# Altium schematic sheet Driver.SchDoc
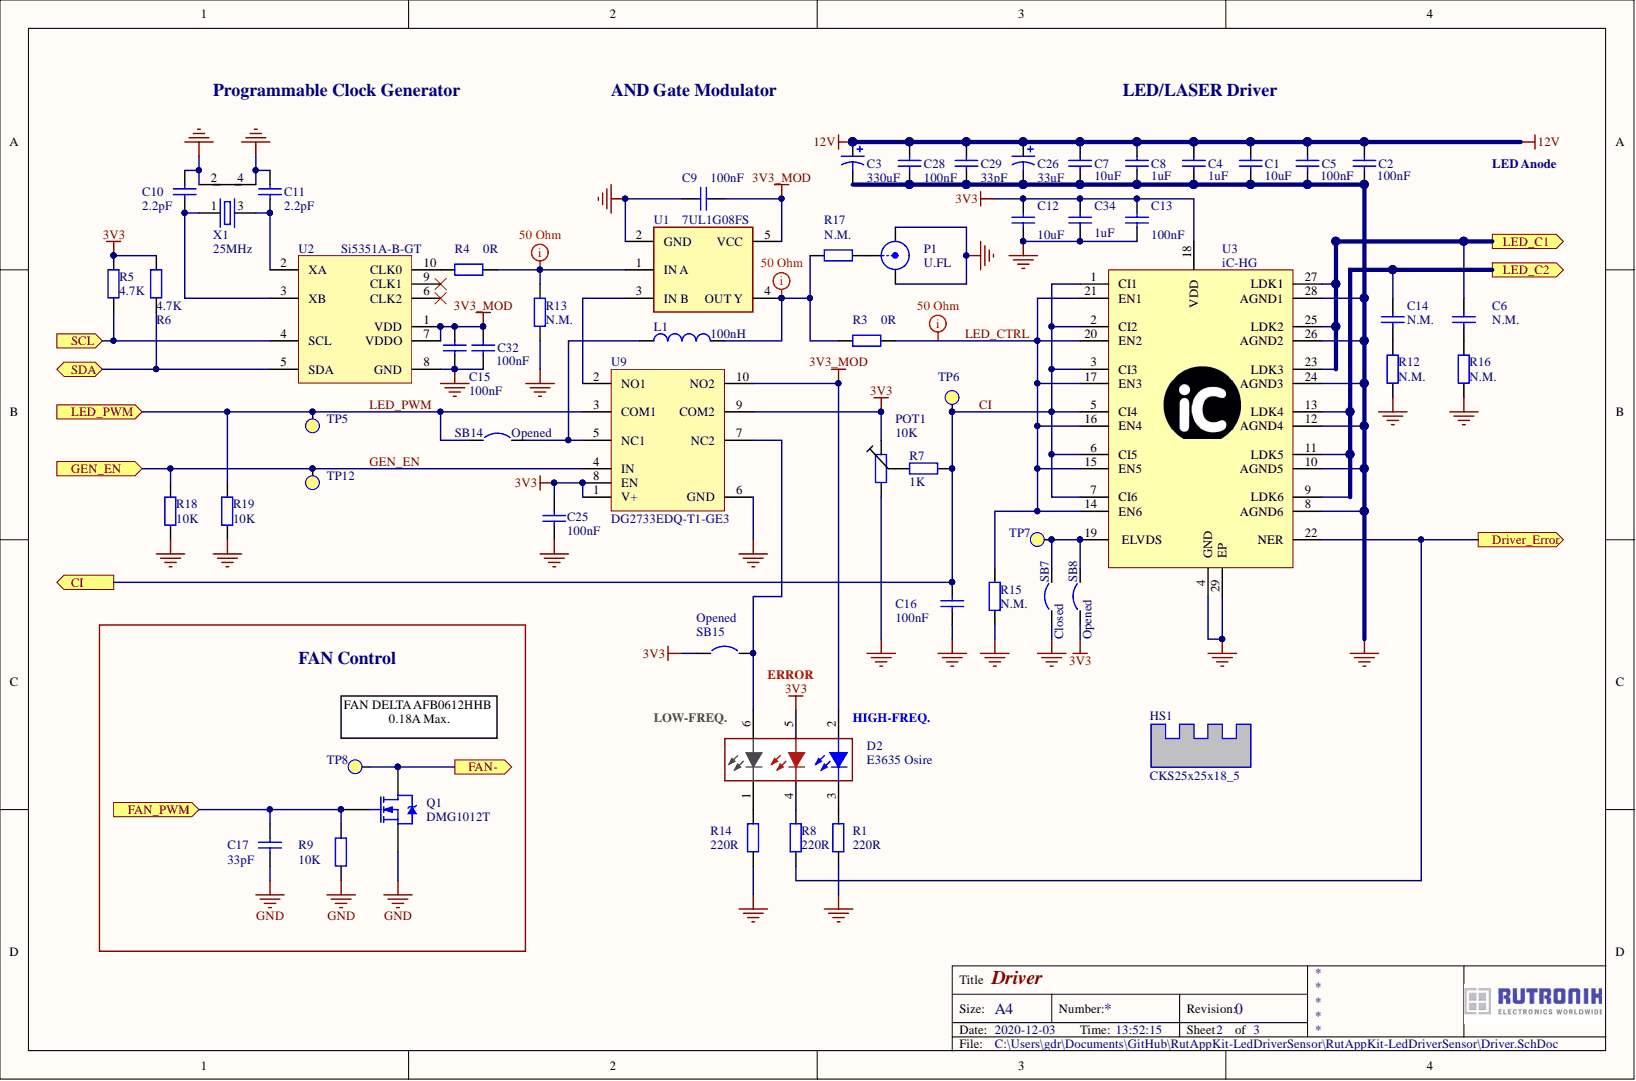
[diagram p0: Driver.SchDoc]

## Parts
C1  value 10uF  [C0805]  (hidden comment: Cap Semi)
C2  value 100nF  [C0402]  (hidden comment: Cap Semi)
C3  value 330uF  [Cap_6.3_7.7_SMD]  (hidden comment: electrolytic capacitor)
C4  value 1uF  [C0805]  (hidden comment: Cap Semi)
C5  value 100nF  [C0402]  (hidden comment: Cap Semi)
C6  value N.M.  [C0603]  (hidden comment: Cap Semi)  (no BOM)
C7  value 10uF  [C0805]  (hidden comment: Cap Semi)
C8  value 1uF  [C0805]  (hidden comment: Cap Semi)
C9  value 100nF  [C0402]  (hidden comment: Cap Semi)
C10  value 2.2pF  [C0402]  (hidden comment: Cap Semi)
C11  value 2.2pF  [C0402]  (hidden comment: Cap Semi)
C12  value 10uF  [C0805]  (hidden comment: Cap Semi)
C13  value 100nF  [C0402]  (hidden comment: Cap Semi)
C14  value N.M.  [C0603]  (hidden comment: Cap Semi)  (no BOM)
C15  value 100nF  [C0402]  (hidden comment: Cap Semi)
C16  value 100nF  [C0402]  (hidden comment: Cap Semi)
C17  value 33pF  [C0402]  (hidden comment: Cap Semi)
C25  value 100nF  [C0402]  (hidden comment: Cap Semi)
C26  value 33uF  [Case-B]  (hidden comment: electrolytic capacitor)
C28  value 100nF  [C0402]  (hidden comment: Cap Semi)
C29  value 33pF  [C0402]  (hidden comment: Cap Semi)
C32  value 100nF  [C0402]  (hidden comment: Cap Semi)
C34  value 1uF  [C0805]  (hidden comment: Cap Semi)
D2  E3635 Osire  [PlCC6_led avago]
HS1  CKS25x25x18_5  [ICKS25x25x18_5]
L1  value 100nH  [L0402]  (hidden comment: Inductor)
P1  U.FL  [U.FL_Connector_Male]
POT1  value 10K  [T93XA]  (hidden comment: Trimmer)
Q1  DMG1012T  [SOT-523_custom]
R1  value 220R  [R0402]  (hidden comment: Res2)
R3  value 0R  [R0402]  (hidden comment: Res2)
R4  value 0R  [R0402]  (hidden comment: Res2)
R5  value 4.7K  [R0402]  (hidden comment: Res2)
R6  value 4.7K  [R0402]  (hidden comment: Res2)
R7  value 1K  [R0402]  (hidden comment: Res2)
R8  value 220R  [R0402]  (hidden comment: Res2)
R9  value 10K  [R0402]  (hidden comment: Res2)
R12  value N.M.  [R0603]  (hidden comment: Res2)  (no BOM)
R13  value N.M.  [R0402]  (hidden comment: Res2)  (no BOM)
R14  value 220R  [R0402]  (hidden comment: Res2)
R15  value N.M.  [R0402]  (hidden comment: Res2)  (no BOM)
R16  value N.M.  [R0603]  (hidden comment: Res2)  (no BOM)
R17  value N.M.  [R0402]  (hidden comment: Res2)  (no BOM)
R18  value 10K  [R0402]  (hidden comment: Res2)
R19  value 10K  [R0402]  (hidden comment: Res2)
SB7  Closed  [SB_0402]
SB8  Opened  [SB_0402 - open]  (no BOM)
SB14  Opened  [SB_0402 - open]  (no BOM)
SB15  Opened  [SB_0402 - open]  (no BOM)
TP5  Test_Point  [TEST_POINT]  (value not drawn)  (no BOM)
TP6  Test_Point  [TEST_POINT]  (value not drawn)  (no BOM)
TP7  Test_Point  [TEST_POINT]  (value not drawn)  (no BOM)
TP8  Test_Point  [TEST_POINT]  (value not drawn)  (no BOM)
TP12  Test_Point  [TEST_POINT]  (value not drawn)  (no BOM)
U1  7UL1G08FS  [SOT-953]
U2  Si5351A-B-GT  [MSOP10]
U3  iC-HG  [QFN28-5x5]
U9  DG2733EDQ-T1-GE3  [MSOP10]
X1  25MHz  [XTAL_3.5x2.5]

## Ports
CI, Driver_Error, FAN-, FAN_PWM, GEN_EN, LED_C1, LED_C2, LED_PWM, SCL, SDA

## Nets
3V3: C12.2 C13.2 C25.1 C34.2 D2.5 POT1.3 R5.1 R6.1 SB8.1 SB15.1 U3.18(VDD) U9.1(V+) U9.8(EN) U9.9(COM2)
3V3_MOD: C9.1 C15.1 C32.1 D2.2 U1.5(VCC) U2.1(VDD) U2.7(VDDO) U9.10(NO2)
12V: C1.2 C2.2 C3.1 C4.2 C5.2 C7.2 C8.2 C26.1 C28.2 C29.1
GND: C1.1 C2.1 C3.2 C4.1 C5.1 C7.1 C8.1 C9.2 C10.1 C11.1 C12.1 C13.1 C15.2 C16.1 C17.2 C25.2 C26.2 C28.1 C29.2 C32.2 C34.1 P1.2 P1.3 POT1.1 Q1.3 R1.2 R9.1 R12.1 R13.2 R14.2 R15.1 R16.1 R18.2 R19.2 SB7.1 U1.2(GND) U2.8(GND) U3.4(GND) U3.8(AGND6) U3.10(AGND5) U3.12(AGND4) U3.24(AGND3) U3.26(AGND2) U3.28(AGND1) U3.29(EP) U9.6(GND) X1.2 X1.4
CI: C16.2 R7.2 TP6.0 U3.1(CI1) U3.2(CI2) U3.3(CI3) U3.5(CI4) U3.6(CI5) U3.7(CI6) ; port CI
Driver_Error: R8.1 U3.22(NER) ; port Driver_Error
FAN-: Q1.2 TP8.0 ; port FAN-
FAN_PWM: C17.1 Q1.1 R9.2 ; port FAN_PWM
GEN_EN: R18.1 TP12.0 U9.4(IN) ; port GEN_EN
LED_C1: C6.2 U3.23(LDK3) U3.25(LDK2) U3.27(LDK1) ; port LED_C1
LED_C2: C14.2 U3.9(LDK6) U3.11(LDK5) U3.13(LDK4) ; port LED_C2
LED_CTRL: R3.2 R15.2 U3.14(EN6) U3.15(EN5) U3.16(EN4) U3.17(EN3) U3.20(EN2) U3.21(EN1)
LED_PWM: R19.1 SB14.1 TP5.0 U9.3(COM1) ; port LED_PWM
SCL: R5.2 U2.4(SCL) ; port SCL
SDA: R6.2 U2.5(SDA) ; port SDA
NetC6_1: C6.1 R16.2
NetC10_2: C10.2 U2.3(XB) X1.1
NetC11_2: C11.2 U2.2(XA) X1.3
NetC14_1: C14.1 R12.2
NetD2_1: D2.1 R14.1
NetD2_3: D2.3 R1.1
NetD2_4: D2.4 R8.2
NetD2_6: D2.6 SB15.2 U9.7(NC2)
NetL1_1: L1.1 SB14.2 U9.5(NC1)
NetL1_2: L1.2 R3.1 R17.1 U1.4(OUT_Y)
NetP1_1: P1.1 R17.2
NetPOT1_2: POT1.2 R7.1
NetR4_1: R4.1 U2.10(CLK0)
NetR4_2: R4.2 R13.1 U1.1(IN_A)
NetSB7_2: SB7.2 SB8.2 TP7.0 U3.19(ELVDS)
NetU1_3: U1.3(IN_B) U9.2(NO1)

Not connected: U2 6(CLK2) 9(CLK1)

## Library data of the parts
C1 C7 C12 = Cap Semi: Capacitor (Semiconductor SIM Model); Manufacturer: Samsung EM; Manufacturer Part No.: CL21B106KOQNNNG; Part Description: Ceramic Capacitor; Specification: X7R, 16V, 10%; Component Kind: Standard; Library Name: Miscellaneous Devices.IntLib; Link: https://www.rutronik24.com/product/samsung+em/cl21b106koqnnng/32265.html; Signal Integrity: Cap Semi; Simulation: CAP; Supplier: Rutronik; Supplier part number: KKS2640
C2 C5 C9 C13 C15 C16 C25 C28 C32 = Cap Semi: Capacitor (Semiconductor SIM Model); Manufacturer: Samsung EM; Manufacturer Part No.: CL05B104KO5NNNC; Part Description: Ceramic Capacitor; Specification: X7R, 16V, 10%; Component Kind: Standard; Library Name: Miscellaneous Devices.IntLib; Link: https://www.rutronik24.com/product/samsung+em/cl05b104ko5nnnc/4748662.html; Signal Integrity: Cap Semi; Simulation: CAP; Supplier: Rutronik; Supplier part number: KKK21419
C3 = Cap Pol1: Manufacturer: Panasonic; Manufacturer Part No.: EEE-FTC331XAP; Specification: 16V, 20%; Component Kind: Standard; Library Name: Miscellaneous Devices.IntLib; Link: https://www.rutronik24.com/search-result/qs:EEE-FTC331XAP/reset:0; Part Description: Electrolytic Capacitor; Signal Integrity: Cap Pol; Simulation: CAP; Supplier: Rutronik; Supplier part number: KEL20909
C4 C8 C34 = Cap Semi: Capacitor (Semiconductor SIM Model); Manufacturer: Samsung EM; Manufacturer Part No.: CL21B105KOFNNNF; Part Description: Ceramic Capacitor; Specification: X7R, 16V, 10%; Component Kind: Standard; Library Name: Miscellaneous Devices.IntLib; Link: https://www.rutronik24.com/product/samsung+em/cl21b105kofnnnf/30238.html; Signal Integrity: Cap Semi; Simulation: CAP; Supplier: Rutronik; Supplier part number: KKK16216
C6 C14 = Cap Semi: Capacitor (Semiconductor SIM Model); Part Description: Ceramic Capacitor; Specification: X7R, 50V, 10%; Library Name: Miscellaneous Devices.IntLib; Signal Integrity: Cap Semi; Simulation: CAP; Supplier: Rutronik
C10 C11 = Cap Semi: Capacitor (Semiconductor SIM Model); Manufacturer: Yageo; Manufacturer Part No.: CC0402CRNPO9BN2R2; Part Description: Ceramic Capacitor; Specification: NP0, 50V, 0.25pf; Component Kind: Standard; Library Name: Miscellaneous Devices.IntLib; Link: https://www.rutronik24.com/product/yageo/cc0402crnpo9bn2r2/30956.html; Signal Integrity: Cap Semi; Simulation: CAP; Supplier: Rutronik; Supplier part number: KKK19155
C17 C29 = Cap Semi: Capacitor (Semiconductor SIM Model); Manufacturer: Samsung EM; Manufacturer Part No.: CL05C330JB5NNNC; Part Description: Ceramic Capacitor; Specification: NP0, 50V, 5%; Component Kind: Standard; Library Name: Miscellaneous Devices.IntLib; Link: https://www.rutronik24.com/product/samsung+em/cl05c330jb5nnnc/29970.html; Signal Integrity: Cap Semi; Simulation: CAP; Supplier: Rutronik; Supplier part number: KKK15073
C26 = Cap Pol1: Manufacturer: AVX; Manufacturer Part No.: TCNL336M016R0200E; Part Description: Tantalum Polymer Capacitor; Specification: 16V, 20%; Component Kind: Standard; Library Name: Miscellaneous Devices.IntLib; Link: https://www.rutronik24.com/search-result/qs:TCNL336M016R0200E/reset:0; Signal Integrity: Cap Pol; Simulation: CAP; Supplier: Rutronik; Supplier part number: TCNL336M016R0200E
D2 = E3635: RGB LED; Manufacturer: OSRAM; Manufacturer Part No.: LRTBGVSR-U4V2-JW+A6BB-D8+S2U2-7Z-20-S; Rule: Clearance Constraint [Clearance = 0.2mm]; Specification: 626 nm, 528 nm, 465 nm; Value: LRTBGVSR-U4V2-JW+A6BB-D8+S2U2-7Z-20-S; Component Kind: Standard; Link: https://www.osram.com/ecat/OSIRE%C2%AE%20E3635%20LRTBGVSR/com/en/class_pim_web_catalog_103489/global/prd_pim_device_5154412/; Part Description: RGB LED; Supplier: Rutronik; Supplier part number: LRTBGVSR-U4V2-JW+A6BB-D8+S2U2-7Z-20-S; Library Name: RutAppKit-LedDriverSensor.SCHLIB
HS1 = CKS25x25x18_5: 5 x 25 x 18,5 mm, pin heatsink quadratic; Manufacturer: Fischer Elektronik; Manufacturer Part No.: ICK S 25x25x18,5; Specification: 25 x 25 x 18,5 mm; Value: ICK S 25x25x18,5; Component Kind: Standard; Library Name: CKS25x25x18_5.SchLib; Link: https://www.rutronik24.com/product/fischer/ick+s+25+x+25+x+18%2C5/12693988.html; Part Description: Pin heatsink quadratic; Supplier: Rutronik; Supplier part number: HSINK2003
L1 = Inductor: Manufacturer: muRata; Manufacturer Part No.: LQG15WHR10J02D; Specification: 150mA 5%; Component Kind: Standard; Description: Inductor; Library Name: Miscellaneous Devices.IntLib; Link: https://www.rutronik24.com/search-result/qs:LQG15WHR10J02D/reset:0; Part Description: Inductor; Signal Integrity: Inductor; Simulation: INDUCTOR; Supplier: Rutronik; Supplier part number: LQG15WHR10J02D
P1 = SMB: Manufacturer: HIROSE; Manufacturer Part No.: U.FL-R-SMT-1(10); Part Description: U.FL Connector; Specification: Male; Value: U.FL-R-SMT-1(10); Component Kind: Standard; Link: https://www.hirose.com/product/en/products/U.FL/U.FL-R-SMT-1%2810%29/; Signal Integrity: Connector; Supplier: Rutronik; Supplier part number: COREC23028; Library Name: RUT_L865_Project_1.SCHLIB
POT1 = Trimmer: Manufacturer: Vishay; Manufacturer Part No.: T93XA103KT20; Specification: 10% 0,5W; Component Kind: Standard; Link: https://www.rutronik24.com/search-result/qs:T93XA103KT20/reset:0; Part Description: Trimmer; Signal Integrity: Res; Simulation: RESISTOR; Supplier: Rutronik; Supplier part number: T93XA103KT20; Library Name: RutAppKit-LedDriverSensor.SCHLIB
Q1 = NMOS-2: N-Channel Power MOSFET; Manufacturer: Diodes Inc.; Manufacturer Part No.: DMG1012T-7; Part Description: N-Channel MOSFET; Specification: 20V, 0.63A; Value: DMG1012T-7; Component Kind: Standard; Library Name: Miscellaneous Devices.IntLib; Link: https://www.rutronik24.com/product/diodes+inc/dmg1012t-7/5168665.html; Simulation: IRF1010; Supplier: Rutronik; Supplier part number: TMOSS6623
R1 R8 R14 = Res2: Manufacturer: ASJ; Manufacturer Part No.: RC0402JR-0710KL; Part Description: Thick Film Resistor; Specification: 5% 0,063W; Component Kind: Standard; Library Name: Miscellaneous Devices.IntLib; Link: https://www.rutronik24.com/product/asj/cr10-221-jk/51883.html; Signal Integrity: Res; Simulation: RESISTOR; Supplier: Rutronik; Supplier part number: WRC35108
R3 R4 = Res2: Manufacturer: Yageo; Manufacturer Part No.: RC04020R; Part Description: Thick Film Resistor; Specification: 5% 0,063W; Component Kind: Standard; Library Name: Miscellaneous Devices.IntLib; Link: https://www.rutronik24.com/product/yageo/ac0402fr-070rl/1082692.html; Signal Integrity: Res; Simulation: RESISTOR; Supplier: Rutronik; Supplier part number: WRC52534
R5 R6 = Res2: Manufacturer: Yageo; Manufacturer Part No.: RC0402JR-134K7L; Part Description: Thick Film Resistor; Specification: 5% 0,063W; Component Kind: Standard; Library Name: Miscellaneous Devices.IntLib; Link: https://www.rutronik24.com/product/yageo/rc0402jr-134k7l/56100.html; Signal Integrity: Res; Simulation: RESISTOR; Supplier: Rutronik; Supplier part number: WRC46913
R7 = Res2: Manufacturer: Yageo; Manufacturer Part No.: RC0402JR-071KL; Part Description: Thick Film Resistor; Specification: 5% 0,063W; Component Kind: Standard; Library Name: Miscellaneous Devices.IntLib; Link: https://www.rutronik24.com/search-result/nojs:1337/qs:RC0402JR-071KL/reset:0; Signal Integrity: Res; Simulation: RESISTOR; Supplier: Rutronik; Supplier part number: WRC33833
R9 R18 R19 = Res2: Manufacturer: ASJ; Manufacturer Part No.: CR10-103-JK; Part Description: Thick Film Resistor; Specification: 5% 0,063W; Component Kind: Standard; Library Name: Miscellaneous Devices.IntLib; Link: https://www.rutronik24.com/product/asj/cr10-103-jk/54295.html; Signal Integrity: Res; Simulation: RESISTOR; Supplier: Rutronik; Supplier part number: WRC40976
R12 R13 R15-R17 = Res2: Part Description: Thick Film Resistor; Specification: 5% 0,063W; Library Name: Miscellaneous Devices.IntLib; Signal Integrity: Res; Simulation: RESISTOR; Supplier: Rutronik
SB7 SB8 SB14 SB15 = Circuit Breaker_close: Circuit Breaker; Manufacturer: Yageo; Manufacturer Part No.: RC04020R; Part Description: Thick Film Resistor; Specification: 5% 0,063W; Value: 0R; Link: https://www.rutronik24.com/product/yageo/ac0402fr-070rl/1082692.html; Revision: July-2002: Re-released for DXP Platform.; Supplier: Rutronik; Supplier part number: WRC52534; Library Name: RutAppKit-LedDriverSensor.SCHLIB
  SB7: Component Kind: Standard
TP5-TP8 TP12 = Test_Point: Test Point; Library Name: Test Point.SchLib
U1 = 7UL1G08FS: 2-Input AND Gate; Manufacturer: TOSHIBA; Manufacturer Part No.: 7UL1G08FS,LF; Rule: Clearance Constraint [Clearance = 0.15mm]; Specification: 3.3V, 2.5ns; Value: 7UL1G08FS,LF; Component Kind: Standard; Library Name: 7UL1G08FS.SchLib; Link: https://toshiba.semicon-storage.com/ap-en/semiconductor/product/general-purpose-logic-ics/detail.7UL1G08FS.html; Part Description: 2-Input AND Gate; Supplier: Rutronik; Supplier part number: 7UL1G08FSLFCT-ND
U2 = Si5351A-B-GT: I2C-PROGRAMMABLE CLOCK; Manufacturer: Silicon Labs; Specification: 200MHz, 3.3V; Component Kind: Standard; Library Name: Si5351A-B-GT.SchLib; Link: https://www.digikey.com/en/products/detail/silicon-labs/SI5351A-B-GT/3679847; Manufacturer Part No.: SI5351A-B-GT; Part Description: I2C-PROGRAMMABLE CLOCK; Supplier: Digi-Key; Supplier part number: 336-2399-5-ND; Value: SI5351A-B-GT
U3 = iC-HG: 3 A LASER SWITCH; Manufacturer: iC Haus; Specification: 200MHz, 3A; Component Kind: Standard; Library Name: iC-HG.SchLib; Link: https://www.ichaus.de/product/iC-HG; Manufacturer Part No.: iC-HG; Part Description: 3 A LASER SWITCH; Supplier: Rutronik; Supplier part number: iC-HG; Value: iC-HG
U9 = DG2733EDQ-T1-GE3: Low Voltage Dual SPDT Analog Switch; Manufacturer: Vishay; Specification: 0.3Ohm,  Dual SPDT; Component Kind: Standard; Library Name: DG2733EDQ-T1-GE3.SchLib; Link: https://www.vishay.com/docs/75646/dg2535e_dg2733e.pdf; Manufacturer Part No.: DG2733EDQ-T1-GE3; Part Description: Low Voltage Dual SPDT Analog Switch; Supplier: Rutronik; Supplier part number: DG2733EDQ-T1-GE3; Value: DG2733EDQ-T1-GE3
X1 = XTL-000011-01: Manufacturer: HKC Europe; Manufacturer Part No.: C7M2500012AEHHF0-RE02; Part Description: XTAL 3.2x2.5mm; Specification: 25MHz,; Value: C7M2500012AEHHF0-RE02; Component Kind: Standard; Link: https://www.rutronik24.com/product/hkc/c7m2500012aehhf0-re02/6739407.html; Supplier: Rutronik; Supplier part number: QUARZ6470; Library Name: RUT_L865_Project_1.SCHLIB
